# Altium schematic sheet PWM_Expander.SchDoc
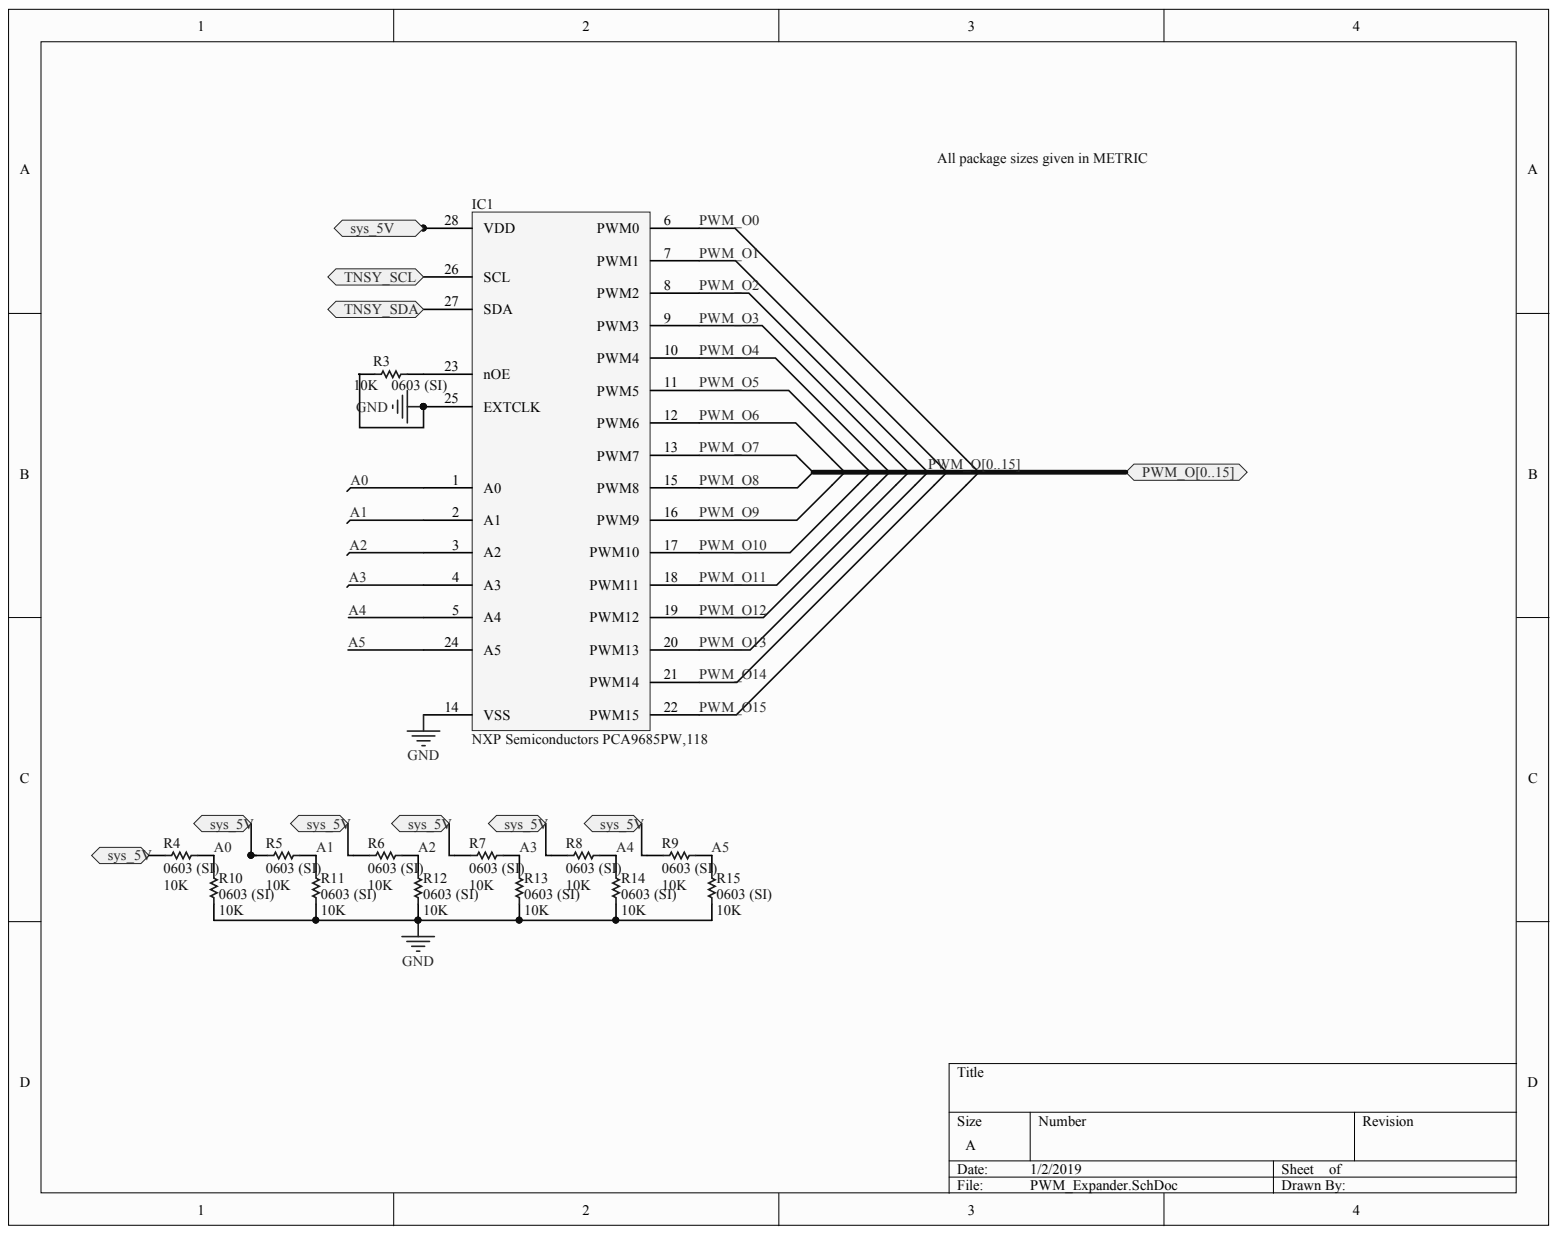
[diagram p0: PWM_Expander.SchDoc]

## Parts
IC1  NXP Semiconductors PCA9685PW,118  Manufacturer Part Number: PCA9685PW,118  [SOP28]
R3  Case/Package: 0603 (SI)  Resistance: 10K  [RESC0603X26X15ML03T05]  (hidden comment: Panasonic ERJ-1GN0R00C)
R4  Case/Package: 0603 (SI)  Resistance: 10K  [RESC0603X26X15ML03T05]  (hidden comment: Panasonic ERJ-1GN0R00C)
R5  Case/Package: 0603 (SI)  Resistance: 10K  [RESC0603X26X15ML03T05]  (hidden comment: Panasonic ERJ-1GN0R00C)
R6  Case/Package: 0603 (SI)  Resistance: 10K  [RESC0603X26X15ML03T05]  (hidden comment: Panasonic ERJ-1GN0R00C)
R7  Case/Package: 0603 (SI)  Resistance: 10K  [RESC0603X26X15ML03T05]  (hidden comment: Panasonic ERJ-1GN0R00C)
R8  Case/Package: 0603 (SI)  Resistance: 10K  [RESC0603X26X15ML03T05]  (hidden comment: Panasonic ERJ-1GN0R00C)
R9  Case/Package: 0603 (SI)  Resistance: 10K  [RESC0603X26X15ML03T05]  (hidden comment: Panasonic ERJ-1GN0R00C)
R10  Case/Package: 0603 (SI)  Resistance: 10K  [RESC0603X26X15ML03T05]  (hidden comment: Panasonic ERJ-1GN0R00C)
R11  Case/Package: 0603 (SI)  Resistance: 10K  [RESC0603X26X15ML03T05]  (hidden comment: Panasonic ERJ-1GN0R00C)
R12  Case/Package: 0603 (SI)  Resistance: 10K  [RESC0603X26X15ML03T05]  (hidden comment: Panasonic ERJ-1GN0R00C)
R13  Case/Package: 0603 (SI)  Resistance: 10K  [RESC0603X26X15ML03T05]  (hidden comment: Panasonic ERJ-1GN0R00C)
R14  Case/Package: 0603 (SI)  Resistance: 10K  [RESC0603X26X15ML03T05]  (hidden comment: Panasonic ERJ-1GN0R00C)
R15  Case/Package: 0603 (SI)  Resistance: 10K  [RESC0603X26X15ML03T05]  (hidden comment: Panasonic ERJ-1GN0R00C)

## Ports
PWM_O0, PWM_O1, PWM_O2, PWM_O3, PWM_O4, PWM_O5, PWM_O6, PWM_O7, PWM_O8, PWM_O9, PWM_O10, PWM_O11, PWM_O12, PWM_O13, PWM_O14, PWM_O15, PWM_O[0..15], SYS_5V, TNSY_SCL, TNSY_SDA

## Nets
GND: IC1.14(VSS) IC1.25(EXTCLK) R3.1 R10.2 R11.2 R12.2 R13.2 R14.2 R15.2
A0: IC1.1(A0) R4.1 R10.1
A1: IC1.2(A1) R5.1 R11.1
A2: IC1.3(A2) R6.1 R12.1
A3: IC1.4(A3) R7.1 R13.1
A4: IC1.5(A4) R8.1 R14.1
A5: IC1.24(A5) R9.1 R15.1
PWM_O0: IC1.6(PWM0) ; port PWM_O[0..15]  [also labelled PWM_O]
PWM_O1: IC1.7(PWM1) ; port PWM_O[0..15]  [also labelled PWM_O]
PWM_O2: IC1.8(PWM2) ; port PWM_O[0..15]  [also labelled PWM_O]
PWM_O3: IC1.9(PWM3) ; port PWM_O[0..15]  [also labelled PWM_O]
PWM_O4: IC1.10(PWM4) ; port PWM_O[0..15]  [also labelled PWM_O]
PWM_O5: IC1.11(PWM5) ; port PWM_O[0..15]  [also labelled PWM_O]
PWM_O6: IC1.12(PWM6) ; port PWM_O[0..15]  [also labelled PWM_O]
PWM_O7: IC1.13(PWM7) ; port PWM_O[0..15]  [also labelled PWM_O]
PWM_O8: IC1.15(PWM8) ; port PWM_O[0..15]  [also labelled PWM_O]
PWM_O9: IC1.16(PWM9) ; port PWM_O[0..15]  [also labelled PWM_O]
PWM_O10: IC1.17(PWM10) ; port PWM_O[0..15]  [also labelled PWM_O]
PWM_O11: IC1.18(PWM11) ; port PWM_O[0..15]  [also labelled PWM_O]
PWM_O12: IC1.19(PWM12) ; port PWM_O[0..15]  [also labelled PWM_O]
PWM_O13: IC1.20(PWM13) ; port PWM_O[0..15]  [also labelled PWM_O]
PWM_O14: IC1.21(PWM14) ; port PWM_O[0..15]  [also labelled PWM_O]
PWM_O15: IC1.22(PWM15) ; port PWM_O[0..15]  [also labelled PWM_O]
TNSY_SCL: IC1.26(SCL) ; port TNSY_SCL
TNSY_SDA: IC1.27(SDA) ; port TNSY_SDA
NetIC1_23: IC1.23(nOE) R3.2
NetIC1_28: IC1.28(VDD) R4.2 R5.2 R6.2 R7.2 R8.2 R9.2 ; port SYS_5V

## Library data of the parts
IC1 = CMP-d7fd1e432ce0083a-4: PCA9685 Series 5.5V 25 mA 1 MHz 12-bit PWM Fm+I2C-Bus LED Controller - TSSOP-28; Component Kind: Standard; Library Name: CommunityVault; Mounting Style: Surface Mount; Packaging: Tape & Reel (TR); RoHS: Compliant
R3-R15 = CMP-5632968-2: Thick Film Resistors - SMD 0201 Zero Ohms 1% Tol; Power Rating: 0.05 W; Voltage Rating (DC): 25.0 V; Component Kind: Standard; Library Name: CommunityVault; Lifecycle Status: Not Listed by Manufacturer; Manufacturer Part Number: ERJ-1GN0R00C; OctopartID: 781db1ede3b7a7da; Octopart Url: https://octopart.com/erj-1gn0r00c-panasonic-16432844; RoHS: Compliant
